# Altium schematic sheet UBX_NORA_B1_SCHEM_0003_Shield_Conn.SchDoc
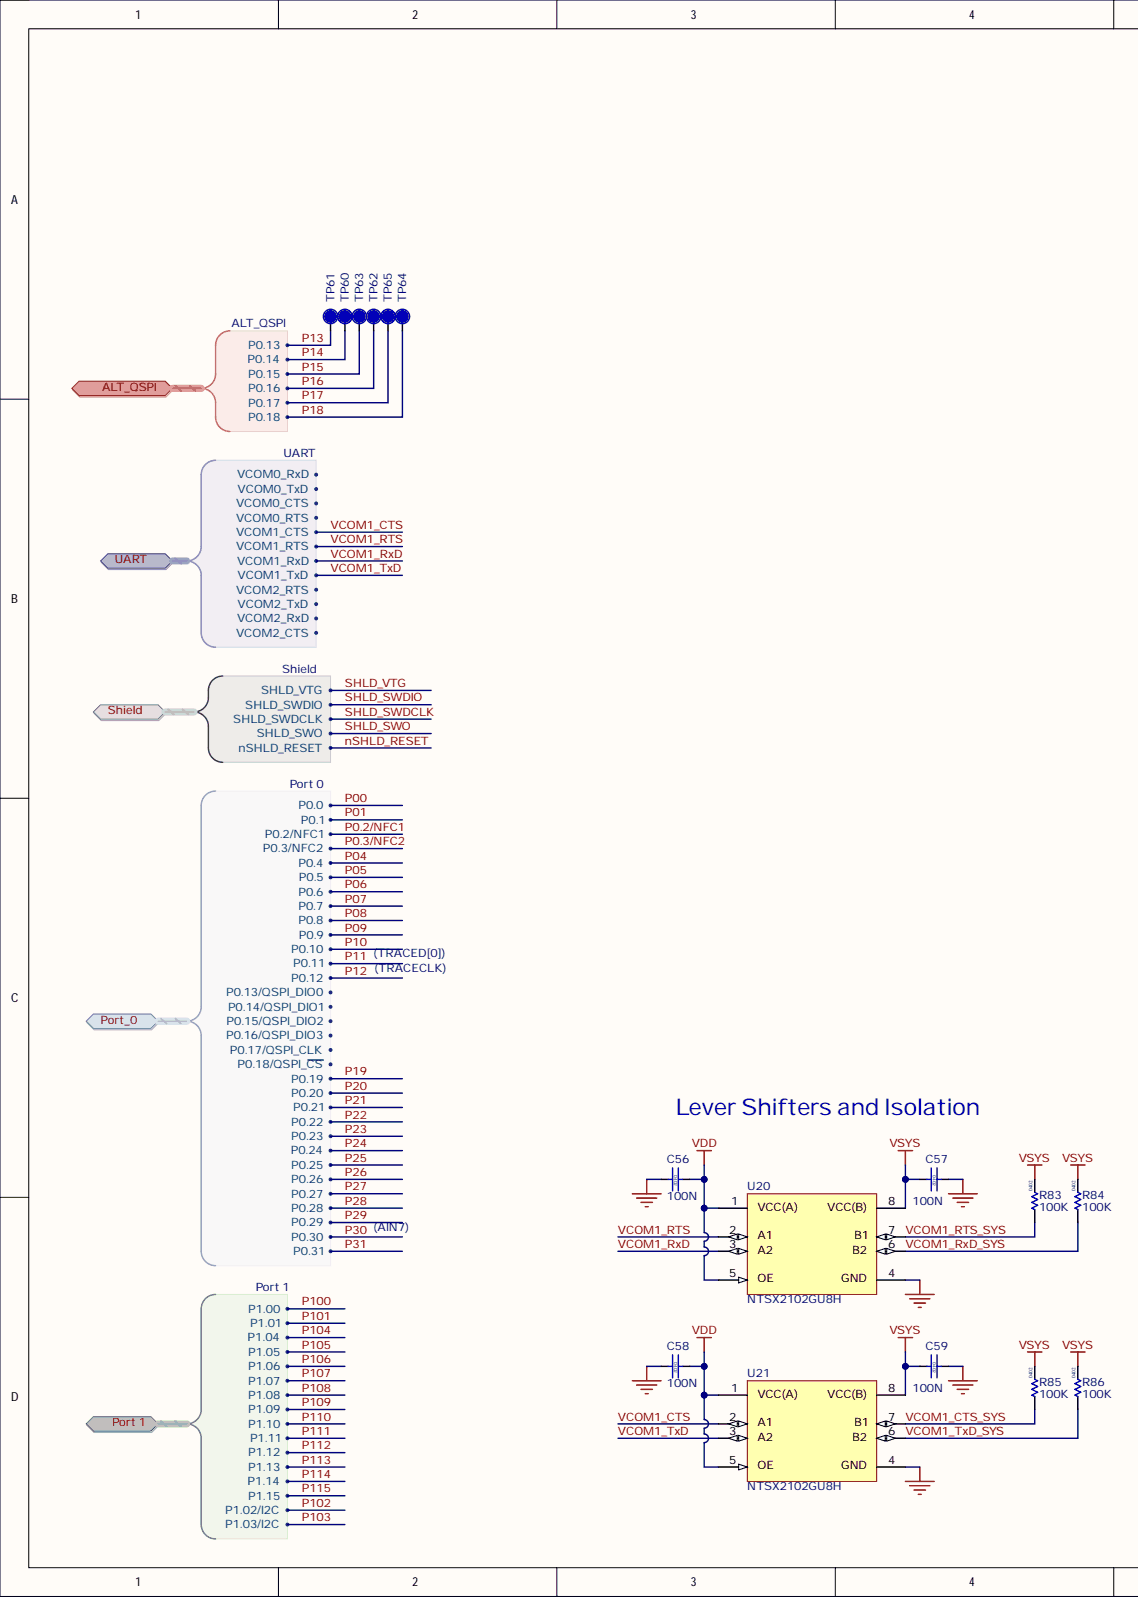
[diagram p0: UBX_NORA_B1_SCHEM_0003_Shield_Conn.SchDoc, left]
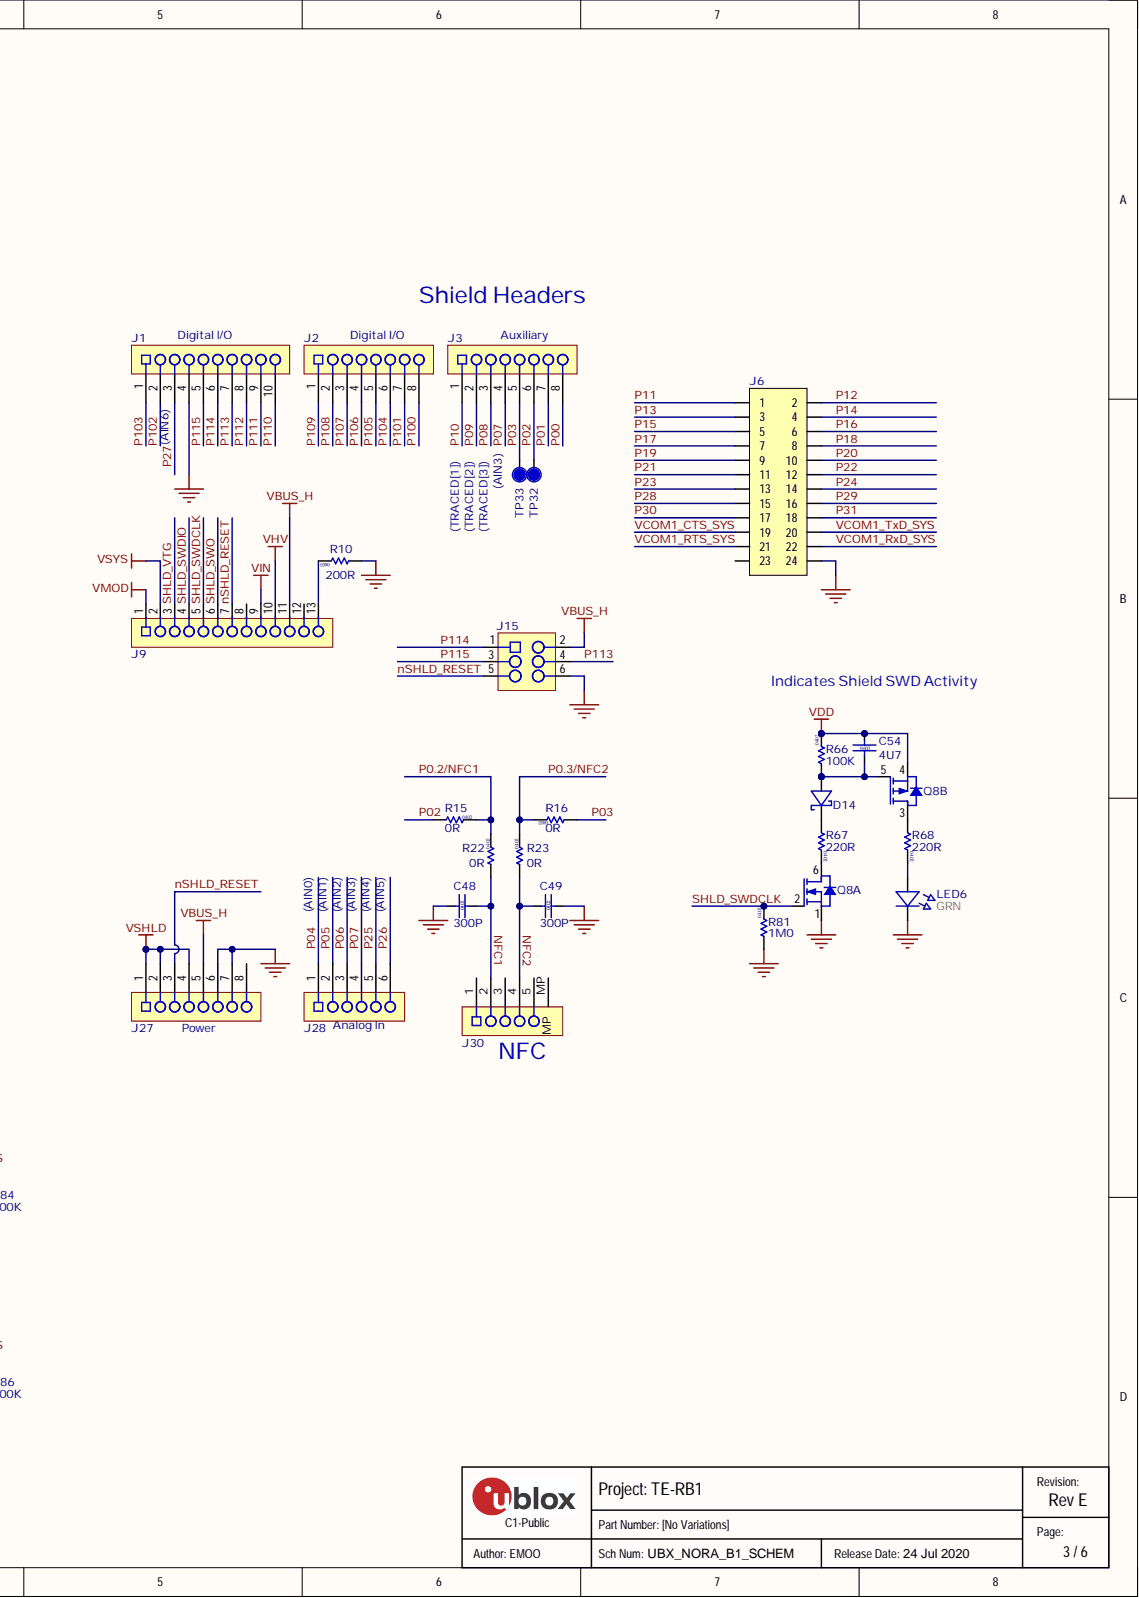
[diagram p1: UBX_NORA_B1_SCHEM_0003_Shield_Conn.SchDoc, right]

## Parts
C48  value 300P  Size: 0402  [Cap 0402 HD]  (hidden comment: UBXH01-0000594300P)
C49  value 300P  Size: 0402  [Cap 0402 HD]  (hidden comment: UBXH01-0000594300P)
C54  value 4U7  Size: 0603  [CAP 0603 HD]  (hidden comment: UBXH01-20020034U7)
C56  value 100N  Size: 0402  [Cap 0402 HD]  (hidden comment: UBXH01-2021002100N)
C57  value 100N  Size: 0402  [Cap 0402 HD]  (hidden comment: UBXH01-2021002100N)
C58  value 100N  Size: 0402  [Cap 0402 HD]  (hidden comment: UBXH01-2021002100N)
C59  value 100N  Size: 0402  [Cap 0402 HD]  (hidden comment: UBXH01-2021002100N)
D14  UBXH07-0000039  [SOD-523]  (value not drawn)
J1  UBXH04-0000788  [SKT-0.1P-SSM-110-L-SV]  (value not drawn)
J2  UBXH04-0000789  [SKT-0.1P-SSM-108-L-SV]  (value not drawn)
J3  UBXH04-0000789  [SKT-0.1P-SSM-108-L-SV]  (value not drawn)
J6  SSM-112-F-DV  [SSM-112-F-DV]  (value not drawn)
J9  UBXH04-0000801  [TSM-113-01-L-SV]  (value not drawn)
J15  UBXH04-0000805  [HDR-0.1P-6Pin-3x2-SMT]  (value not drawn)
J27  UBXH04-0000789  [SKT-0.1P-SSM-108-L-SV]  (value not drawn)
J28  UBXH04-0000790  [SKT-0.1P-SSM-106-L-SV]  (value not drawn)
J30  UBXH04-0000800  [Molex 051281-0594]  (value not drawn)
LED6  Color: GRN  [LED_0603_GREEN]  (hidden comment: UBXH11-0000001)
Q8  UBXH20-0000084  [SOT-563]  (value not drawn)  (parts A,B)
R10  value 200R  Size: 0603  [RES 0603 HD]  (hidden comment: UBXH18-0007056200R)
R15  value 0R  Size: 0402  [RES 0402 HD]  (hidden comment: UBXH18-00030020R)
R16  value 0R  Size: 0402  [RES 0402 HD]  (hidden comment: UBXH18-00030020R)
R22  value 0R  Size: 0402  [RES 0402 HD]  (hidden comment: UBXH18-00030020R)
R23  value 0R  Size: 0402  [RES 0402 HD]  (hidden comment: UBXH18-00030020R)
R66  value 100K  Size: 0402  [RES 0402 HD]  (hidden comment: UBXH18-0000005100K)
R67  value 220R  Size: 0402  [RES 0402 HD]  (hidden comment: UBXH18-0008033220R)
R68  value 220R  Size: 0402  [RES 0402 HD]  (hidden comment: UBXH18-0008033220R)
R81  value 1M0  Size: 0402  [RES 0402 HD]  (hidden comment: UBXH18-00081211M0)
R83  value 100K  Size: 0402  [RES 0402 HD]  (hidden comment: UBXH18-0000005100K)
R84  value 100K  Size: 0402  [RES 0402 HD]  (hidden comment: UBXH18-0000005100K)
R85  value 100K  Size: 0402  [RES 0402 HD]  (hidden comment: UBXH18-0000005100K)
R86  value 100K  Size: 0402  [RES 0402 HD]  (hidden comment: UBXH18-0000005100K)
TP32  TP  [TP10R]  (no BOM)
TP33  TP  [TP10R]  (no BOM)
TP60  TP  [TP10R]  (no BOM)
TP61  TP  [TP10R]  (no BOM)
TP62  TP  [TP10R]  (no BOM)
TP63  TP  [TP10R]  (no BOM)
TP64  TP  [TP10R]  (no BOM)
TP65  TP  [TP10R]  (no BOM)
U20  Manufacturer Part Number 1: NTSX2102GU8H  [QFN0.4P3x1-8Pin]  (hidden comment: UBXH10-0000106)
U21  Manufacturer Part Number 1: NTSX2102GU8H  [QFN0.4P3x1-8Pin]  (hidden comment: UBXH10-0000106)

## Ports
ALT_QSPI, ALT_QSPI.P0.13, ALT_QSPI.P0.14, ALT_QSPI.P0.15, ALT_QSPI.P0.16, ALT_QSPI.P0.17, ALT_QSPI.P0.18, Port 1, Port 1.P1.00, Port 1.P1.01, Port 1.P1.02/I2C, Port 1.P1.03/I2C, Port 1.P1.04, Port 1.P1.05, Port 1.P1.06, Port 1.P1.07, Port 1.P1.08, Port 1.P1.09, Port 1.P1.10, Port 1.P1.11, Port 1.P1.12, Port 1.P1.13, Port 1.P1.14, Port 1.P1.15, Port_0, Port_0.P0.0, Port_0.P0.1, Port_0.P0.2/NFC1, Port_0.P0.3/NFC2, Port_0.P0.4, Port_0.P0.5, Port_0.P0.6, Port_0.P0.7, Port_0.P0.8, Port_0.P0.9, Port_0.P0.10, Port_0.P0.11, Port_0.P0.12, Port_0.P0.13/QSPI_DIO0, Port_0.P0.14/QSPI_DIO1, Port_0.P0.15/QSPI_DIO2, Port_0.P0.16/QSPI_DIO3, Port_0.P0.17/QSPI_CLK, Port_0.P0.18/QSPI_~{CS}, Port_0.P0.19, Port_0.P0.20, Port_0.P0.21, Port_0.P0.22, Port_0.P0.23, Port_0.P0.24, Port_0.P0.25, Port_0.P0.26, Port_0.P0.27, Port_0.P0.28, Port_0.P0.29, Port_0.P0.30, Port_0.P0.31, Shield, Shield.nSHLD_RESET, Shield.SHLD_SWDCLK, Shield.SHLD_SWDIO, Shield.SHLD_SWO, Shield.SHLD_VTG, UART, UART.VCOM0_CTS, UART.VCOM0_RTS, UART.VCOM0_RxD, UART.VCOM0_TxD, UART.VCOM1_CTS, UART.VCOM1_RTS, UART.VCOM1_RxD, UART.VCOM1_TxD, UART.VCOM2_CTS, UART.VCOM2_RTS, UART.VCOM2_RxD, UART.VCOM2_TxD

## Nets
GND: C48.1 C49.2 C56.2 C57.1 C58.2 C59.1 J1.4 J6.24 J15.6 J27.6 J27.7 LED6.1 Q8.1 R10.1 R81.1 U20.4(GND) U21.4(GND)
VBUS_H: J9.11 J15.2 J27.5
VDD: C54.1 C56.1 C58.1 Q8.4 R66.2 U20.1(VCC(A)) U20.5(OE) U21.1(VCC(A)) U21.5(OE)
VHV: J9.10
VIN: J9.9
VMOD: J9.1
VSHLD: J27.1 J27.2 J27.4
VSYS: C57.2 C59.2 J9.2 R83.2 R84.2 R85.2 R86.2 U20.8(VCC(B)) U21.8(VCC(B))
NFC1: C48.2 J30.2 R22.1
NFC2: C49.1 J30.4 R23.1
nSHLD_RESET: J9.7 J15.5 J27.3 ; port Shield
P00: J3.8 ; port Port_0
P0.2/NFC1: R15.2 R22.2 ; port Port_0
P0.3/NFC2: R16.2 R23.2 ; port Port_0
P01: J3.7 ; port Port_0
P02: J3.6 R15.1 TP32.1
P03: J3.5 R16.1 TP33.1
P04: J28.1 ; port Port_0
P05: J28.2 ; port Port_0
P06: J28.3 ; port Port_0
P07: J3.4 J28.4 ; port Port_0
P08: J3.3 ; port Port_0
P09: J3.2 ; port Port_0
P10: J3.1 ; port Port_0
P11: J6.1 ; port Port_0
P12: J6.2 ; port Port_0
P13: J6.3 TP61.1 ; port ALT_QSPI
P14: J6.4 TP60.1 ; port ALT_QSPI
P15: J6.5 TP63.1 ; port ALT_QSPI
P16: J6.6 TP62.1 ; port ALT_QSPI
P17: J6.7 TP65.1 ; port ALT_QSPI
P18: J6.8 TP64.1 ; port ALT_QSPI
P19: J6.9 ; port Port_0
P20: J6.10 ; port Port_0
P21: J6.11 ; port Port_0
P22: J6.12 ; port Port_0
P23: J6.13 ; port Port_0
P24: J6.14 ; port Port_0
P25: J28.5 ; port Port_0
P26: J28.6 ; port Port_0
P27: J1.3 ; port Port_0
P28: J6.15 ; port Port_0
P29: J6.16 ; port Port_0
P30: J6.17 ; port Port_0
P31: J6.18 ; port Port_0
P100: J2.8 ; port Port 1
P101: J2.7 ; port Port 1
P102: J1.2 ; port Port 1
P103: J1.1 ; port Port 1
P104: J2.6 ; port Port 1
P105: J2.5 ; port Port 1
P106: J2.4 ; port Port 1
P107: J2.3 ; port Port 1
P108: J2.2 ; port Port 1
P109: J2.1 ; port Port 1
P110: J1.10 ; port Port 1
P111: J1.9 ; port Port 1
P112: J1.8 ; port Port 1
P113: J1.7 J15.4 ; port Port 1
P114: J1.6 J15.1 ; port Port 1
P115: J1.5 J15.3 ; port Port 1
SHLD_SWDCLK: J9.5 Q8.2 R81.2 ; port Shield
SHLD_SWDIO: J9.4 ; port Shield
SHLD_SWO: J9.6 ; port Shield
SHLD_VTG: J9.3 ; port Shield
VCOM1_CTS: U21.2(A1) ; port UART
VCOM1_CTS_SYS: J6.19 R85.1 U21.7(B1)
VCOM1_RTS: U20.2(A1) ; port UART
VCOM1_RTS_SYS: J6.21 R83.1 U20.7(B1)
VCOM1_RxD: U20.3(A2) ; port UART
VCOM1_RxD_SYS: J6.22 R84.1 U20.6(B2)
VCOM1_TxD: U21.3(A2) ; port UART
VCOM1_TxD_SYS: J6.20 R86.1 U21.6(B2)
NetC54_2: C54.2 D14.A Q8.5 R66.1
NetD14_C: D14.C R67.1
NetJ9_13: J9.13 R10.2
NetLED6_2: LED6.2 R68.2
NetQ8_3: Q8.3 R68.1
NetQ8_6: Q8.6 R67.2
N00001: ; port UART
N00002: ; port UART
N00003: ; port UART
N00004: ; port UART
N00005: ; port UART
N00006: ; port UART
N00007: ; port UART
N00008: ; port UART
N00009: ; port Port_0
N00010: ; port Port_0
N00011: ; port Port_0
N00012: ; port Port_0
N00013: ; port Port_0
N00014: ; port Port_0

Not connected: J6 23; J9 8 12; J27 8; J30 1 3 5 MP

## Library data of the parts
C48 C49 = UBXH01-0000594: CAP CER C0G 0402 300P 50V; Manufacturer: Murata Manufacturing Company, Ltd.; Manufacturer P/N: GRM1555C1H301JA01D; Database Table Name: Passive - Capacitor; Editor: eric.moog; Env: ROHS; Mfg P/N Released: No; Mfg P/N Split: 100; Mfg P/N Status: Active; Perferred: PREF; Permitted Use: Volume Products; Component Kind: Standard; Library Name: UBX_Parts_DB_Lib.DbLib; Max Operating Temp: 125°C; Max Voltage: 50V; Min Operating Temp: -55°C; Part Number: UBXH01-0000594; Part Type: Capacitor; RefDes: C; Signal Integrity: Cap Semi; Simulation: CAP; UBX Wiki: https://wiki.u-blox.com/bin/view/Operations/PartDetails?index=38856
C54 = UBXH01-2002003: CAP CER X5R 0603 4U7 10% 6.3V; Manufacturer: Murata Manufacturing Company, Ltd.; Manufacturer P/N: GRM188R61C475KE11; Database Table Name: Passive - Capacitor; Editor: philippe.largier; Env: GREEN; Mfg P/N Released: Yes; Mfg P/N Split: 100; Mfg P/N Status: Active; Perferred: PREF; Permitted Use: Volume Products; Released by: roland.rust; Component Kind: Standard; Library Name: UBX_Parts_DB_Lib.DbLib; Manufacturer 2: Murata Manufacturing Company, Ltd.; Manufacturer 3: Samsung Electro-Mechanics; Manufacturer P/N 2: GRM188R61E475KE11; Manufacturer P/N 3: CL10A475KO8NNN; Max Voltage: 6.3V; Mfg P/N Datasheet: https://wiki.u-blox.com/bin/view/Generic/Docs/DocumentSummary?index=30154; Mfg P/N Datasheet 2: https://wiki.u-blox.com/bin/view/Generic/Docs/DocumentSummary?index=30500; Mfg P/N Datasheet 3: https://wiki.u-blox.com/bin/view/Generic/Docs/DocumentSummary?index=28177; Mfg P/N Released 2: Yes; Mfg P/N Released 3: Yes; Mfg P/N Split 2: 0; Mfg P/N Split 3: 0; Mfg P/N Status 2: Active; Mfg P/N Status 3: NRND; Part Number: UBXH01-2002003; Part Type: Capacitor; RefDes: C; Release Data: 2016-02-02T16:39:45; Signal Integrity: Cap Semi; Simulation: CAP; Tolerance: 10%; UBX Wiki: https://wiki.u-blox.com/bin/view/Operations/PartDetails?index=5742
C56-C59 = UBXH01-2021002: CAP CER X5R 0402 100N 10% 10V; Manufacturer: Murata Manufacturing Company, Ltd.; Manufacturer P/N: GRM155R61A104KA01; Database Table Name: Passive - Capacitor; Editor: roland.rust; Env: GREEN; Mfg P/N Released: Yes; Mfg P/N Split: 100; Mfg P/N Status: NRND; Perferred: PREF; Permitted Use: Volume Products; Component Kind: Standard; Library Name: UBX_Parts_DB_Lib.DbLib; Manufacturer 2: Samsung Electro-Mechanics; Manufacturer P/N 2: CL05A104KP5NNN; Max Voltage: 10V; Mfg P/N Datasheet 2: https://wiki.u-blox.com/bin/view/Generic/Docs/DocumentSummary?index=28113; Mfg P/N Released 2: Yes; Mfg P/N Split 2: 0; Mfg P/N Status 2: Active; Part Number: UBXH01-2021002; Part Type: Capacitor; RefDes: C; Release Data: 2005-07-22T13:59:44; Signal Integrity: Cap Semi; Simulation: CAP; Tolerance: 10%; UBX Wiki: https://wiki.u-blox.com/bin/view/Operations/PartDetails?index=5759; Released by: roland.rust
D14 = UBXH07-0000039: SCHOTTKY BARRIER DIODE 30V 200mA; Manufacturer: ON Semiconductor; Manufacturer P/N: RB521S30T1G; Database Table Name: Discrete - Diode; Editor: matej.budin; Env: ROHS; Mfg P/N Released: Yes; Mfg P/N Split: 100; Mfg P/N Status: Active; Perferred: PREF; Permitted Use: Volume Products; Released by: pierpaolo.arban; Value: RB521S30T1G; Component Kind: Standard; Library Name: UBX_Parts_DB_Lib.DbLib; Max Current: 200mA; Max Voltage: 30V; Mfg P/N Datasheet: https://wiki.u-blox.com/bin/view/Generic/Docs/DocumentSummary?index=22755; Part Number: UBXH07-0000039; Part Type: Diode; RefDes: D; Release Data: 2015-06-18T10:04:48; UBX Wiki: https://wiki.u-blox.com/bin/view/Operations/PartDetails?index=22753
J1 = UBXH04-0000788: 10 -PIN RECEPTACLE BOTTOM OR TOP ENTRY VERT 2.54MM 50V 2.5A; Manufacturer: Amphenol Corporation; Manufacturer P/N: 91601-310GLF; Database Table Name: Electromechanical - Connector; Editor: eric.moog; Env: ROHS; Mfg P/N Released: No; Mfg P/N Split: 100; Mfg P/N Status: Active; Permitted Use: Support Products; Value: 91601-310GLF; Component Kind: Standard; Library Name: UBX_Parts_DB_Lib.DbLib; Max Current: 2.5A; Max Operating Temp: 150°C; Max Voltage: 50V; Mfg P/N Datasheet: https://wiki.u-blox.com/bin/view/Generic/Docs/DocumentSummary?index=38560; Min Operating Temp: -65°C; Part Number: UBXH04-0000788; Part Type: Connector; Perferred: N/A; RefDes: J; UBX Wiki: https://wiki.u-blox.com/bin/view/Operations/PartDetails?index=38561
J2 J3 J27 = UBXH04-0000789: 8 -PIN RECEPTACLE BOTTOM OR TOP ENTRY VERT 2.54MM 50V 2.5A; Manufacturer: Amphenol Corporation; Manufacturer P/N: 91601-308GLF; Database Table Name: Electromechanical - Connector; Editor: eric.moog; Env: ROHS; Mfg P/N Released: No; Mfg P/N Split: 100; Mfg P/N Status: Active; Permitted Use: Support Products; Value: 91601-308GLF; Component Kind: Standard; Library Name: UBX_Parts_DB_Lib.DbLib; Max Current: 2.5A; Max Operating Temp: 150°C; Max Voltage: 50V; Mfg P/N Datasheet: https://wiki.u-blox.com/bin/view/Generic/Docs/DocumentSummary?index=38560; Min Operating Temp: -65°C; Part Number: UBXH04-0000789; Part Type: Connector; Perferred: N/A; RefDes: J; UBX Wiki: https://wiki.u-blox.com/bin/view/Operations/PartDetails?index=38563
J6 = UBXH04-0000802: 2-ROW SMD PCB CONN SOCKET 100MIL GRID 24-PIN 0.64MM SQUARE 405V 5.2A; Manufacturer: Samtec; Artikkelnr Noca: N.A.; Database Table Name: Electromechanical - Connector; Editor: eric.moog; Env: ROHS; Mfg P/N Released: No; Mfg P/N Split: 100; Mfg P/N Status: Active; Perferred: PREF; Permitted Use: Support Products; Component Kind: Standard; ComponentLink1Description: Datasheet; ComponentLink2Description: Supplier link; Footprint Doc: SOCKET_2x12_SMD-2.54mm; LatestRevisionDate: 2019-08-05; Library Name: UBX_Parts_DB_Lib.DbLib; Manufacturer 1: Samtec; Manufacturer P/N: SSM-112-F-DV; Manufacturer Part Number 1: SSM-112-F-DV; Max Current: 5.2A; Max Operating Temp: 125°C; Max Voltage: 405V; Mfg P/N Datasheet: https://wiki.u-blox.com/bin/view/Generic/Docs/DocumentSummary?index=21260; Min Operating Temp: -55°C; Part Number: UBXH04-0000802; Part number IPS: N.A.; Part Type: Connector; Pin Count: 24; RefDes: J; Supplier 1: Cabcon; Supplier 2: Samtec; Supplier Part Number 1: 2171-212MS0CUNR1; Supplier Part Number 2: SSM-112-F-DV; UBX Wiki: https://wiki.u-blox.com/bin/view/Operations/PartDetails?index=38898; Value: SSM-112-F-DV
J9 = UBXH04-0000801: 1-ROW SMD-PCB CONN 13 -PIN 2.54MM STRIP 475V 4.1A; Manufacturer: Samtec; Database Table Name: Electromechanical - Connector; Editor: eric.moog; Env: ROHS; Mfg P/N Released: No; Mfg P/N Split: 100; Mfg P/N Status: Active; Perferred: PREF; Permitted Use: Support Products; Value: TSM-113-01-L-SV; Component Kind: Standard; Library Name: UBX_Parts_DB_Lib.DbLib; Manufacturer P/N: TSM-113-01-L-SV; Max Current: 4.1A; Max Operating Temp: 125°C; Max Voltage: 475V; Mfg P/N Datasheet: https://wiki.u-blox.com/bin/view/Generic/Docs/DocumentSummary?index=15851; Min Operating Temp: -55°C; Part Number: UBXH04-0000801; Part Type: Connector; RefDes: J; UBX Wiki: https://wiki.u-blox.com/bin/view/Operations/PartDetails?index=38895
J15 = UBXH04-0000805: 2-ROW CONN SMD VERT 2X06 PIN 2.54MM 100V 2A; Manufacturer: molex; Manufacturer P/N: 0015912060; Database Table Name: Electromechanical - Connector; Editor: eric.moog; Env: ROHS; Mfg P/N Released: No; Mfg P/N Split: 100; Mfg P/N Status: Active; Perferred: PREF; Permitted Use: Support Products; Value: 0015912060; Component Kind: Standard; Library Name: UBX_Parts_DB_Lib.DbLib; Max Current: 2A; Max Operating Temp: 85°C; Max Voltage: 100V; Mfg P/N Datasheet: https://wiki.u-blox.com/bin/view/Generic/Docs/DocumentSummary?index=38946; Min Operating Temp: -40°C; Part Number: UBXH04-0000805; Part Type: Connector; RefDes: J; UBX Wiki: https://wiki.u-blox.com/bin/view/Operations/PartDetails?index=38947
J28 = UBXH04-0000790: 6 -PIN RECEPTACLE BOTTOM OR TOP ENTRY VERT 2.54MM 50V 2.5A; Manufacturer: Amphenol Corporation; Manufacturer P/N: 91601-306GLF; Database Table Name: Electromechanical - Connector; Editor: eric.moog; Env: ROHS; Mfg P/N Released: No; Mfg P/N Split: 100; Mfg P/N Status: Active; Permitted Use: Support Products; Value: 91601-306GLF; Component Kind: Standard; Library Name: UBX_Parts_DB_Lib.DbLib; Max Current: 2.5A; Max Operating Temp: 150°C; Max Voltage: 50V; Mfg P/N Datasheet: https://wiki.u-blox.com/bin/view/Generic/Docs/DocumentSummary?index=38560; Min Operating Temp: -65°C; Part Number: UBXH04-0000790; Part Type: Connector; Perferred: N/A; RefDes: J; UBX Wiki: https://wiki.u-blox.com/bin/view/Operations/PartDetails?index=38565
J30 = UBXH04-0000800: CONN NON ZIF FPC MOLEX 51281 0.5MM PITCH 5-PIN TOP AND BOTTOM 50V 500mA; Manufacturer 1: KYOCERA AVX; Manufacturer P/N: 0512810598; Manufacturer Part Number 1: 046284005001846; Part Name: CON FFC/FPC 0.50mm 5Pos R/A; Part_Type: Connector; Component Mounting Type: SMT; Database Table Name: Electromechanical - Connector; Editor: eric.moog; Env: ROHS; Item_Phase: Preliminary; Keyed: No; Mfg P/N Split: 100; Mfg P/N Status: Active; Perferred: PREF; Permitted Use: Support Products; Rows: 1; Use in new designs: Yes; Value: 0512810598; Color: White; Component Kind: Standard; Connector Type: FPC; Height: 1.3mm; Length: 4.05mm; Library Name: UBX_Parts_DB_Lib.DbLib; Locking: No; Manufacturer: molex; Max Current: 500mA; Max Operating Temp: 85°C; Max Voltage: 50V; Mfg P/N Datasheet: https://wiki.u-blox.com/bin/view/Generic/Docs/DocumentSummary?index=38892; Mfg P/N Released: No; Min Operating Temp: -30°C; Part Number: UBXH04-0000800; Part Type: Connector; Pin Pitch: 0.5mm; Pins: 5; RefDes: J; UBX Wiki: https://wiki.u-blox.com/bin/view/Operations/PartDetails?index=38893; Voltage: 50V; Width: 4.4mm
LED6 = UBXH11-0000001: LED SMD 0603 GREEN 2.5V 20mA; Manufacturer: Kingbright; Manufacturer P/N: APT1608SGC; Database Table Name: Discrete - LED; Editor: gianni.falleroni; Env: ROHS; Mfg P/N Released: Yes; Mfg P/N Split: 50; Mfg P/N Status: Active; Permitted Use: Support Products; Released by: alex.claville; Component Kind: Standard; Library Name: UBX_Parts_DB_Lib.DbLib; Manufacturer 2: Lite-on Technology Corporation; Manufacturer P/N 2: LTST-C190KGKT; Max Current: 20mA; Max Voltage: 2.5V; Mfg P/N Datasheet: https://wiki.u-blox.com/bin/view/Generic/Docs/DocumentSummary?index=37527; Mfg P/N Datasheet 2: https://wiki.u-blox.com/bin/view/Generic/Docs/DocumentSummary?index=16220; Mfg P/N Released 2: Yes; Mfg P/N Split 2: 50; Mfg P/N Status 2: Active; Part Number: UBXH11-0000001; Part Type: LED; Perferred: N/A; RefDes: DS; Release Data: 2022-09-27T14:26:54; Size: 0603; UBX Wiki: https://wiki.u-blox.com/bin/view/Operations/PartDetails?index=7902; Value: GREEN
Q8 = UBXH20-0000084: SMALL SIGNAL COMPLEMENTARY W/ ESD PROTECTION MOSFET SOT-563-6 PACKAGE; Manufacturer: ON Semiconductor; Manufacturer P/N: NTZD3155CT1G; Database Table Name: Discrete - Transistor; Editor: pierpaolo.arban; Env: ROHS; Mfg P/N Released: Yes; Mfg P/N Split: 100; Mfg P/N Status: Active; Perferred: PREF; Permitted Use: Support Products; Released by: matej.budin; Value: NTZD3155CT1G; Component Kind: Standard; Library Name: UBX_Parts_DB_Lib.DbLib; Max Operating Temp: 155°C; Mfg P/N Datasheet: https://wiki.u-blox.com/bin/view/Generic/Docs/DocumentSummary?index=9037; Min Operating Temp: -55°C; Part Number: UBXH20-0000084; Part Type: Transistor; RefDes: Q; Release Data: 2023-01-26T11:59:16; UBX Wiki: https://wiki.u-blox.com/bin/view/Operations/PartDetails?index=37717
R10 = UBXH18-0007056: RES THICK FILM CHIP 0603 200R 5% 100mW; Database Table Name: Passive - Resistor; Editor: andreas.thiel; Env: ROHS; Perferred: NONPREF; Permitted Use: Volume Products; Component Kind: Standard; Library Name: UBX_Parts_DB_Lib.DbLib; Max Power: 100mW; Part Number: UBXH18-0007056; Part Type: Resistor; RefDes: R; Signal Integrity: Res; Simulation: RESISTOR; Tolerance: 5%; UBX Wiki: https://wiki.u-blox.com/bin/view/Operations/PartDetails?index=6682
R15 R16 R22 R23 = UBXH18-0003002: RES THICK FILM CHIP 0402 0R 0 100mW; Manufacturer: KOA; Manufacturer P/N: RK73Z1ETTD; Database Table Name: Passive - Resistor; Editor: charlotte.biber; Env: ROHS; Mfg P/N Released: Yes; Mfg P/N Split: 100; Mfg P/N Status: NRND; Perferred: PREF; Permitted Use: Volume Products; Released by: peter.mueller; Tolerance: 0; Component Kind: Standard; Library Name: UBX_Parts_DB_Lib.DbLib; Manufacturer 2: YAGEO; Manufacturer P/N 2: 2322_705_91001_L; Max Power: 100mW; Mfg P/N Released 2: Yes; Mfg P/N Split 2: 0; Mfg P/N Status 2: NRND; Part Number: UBXH18-0003002; Part Type: Resistor; RefDes: R; Release Data: 2012-06-05T09:49:55; Signal Integrity: Res; Simulation: RESISTOR; UBX Wiki: https://wiki.u-blox.com/bin/view/Operations/PartDetails?index=6611
R66 R83-R86 = UBXH18-0000005: RES THICK FILM CHIP 0402 100K 1% 63mW; Manufacturer: KOA; Manufacturer P/N: RK73H1ETTP1003F; Database Table Name: Passive - Resistor; Editor: dario.antonaz; Env: ROHS; Mfg P/N Released: Yes; Mfg P/N Split: 100; Mfg P/N Status: Active; Permitted Use: Volume Products; Released by: sandro.sestan; Component Kind: Standard; Library Name: UBX_Parts_DB_Lib.DbLib; Manufacturer 2: ROHM; Manufacturer P/N 2: MCR01MZPF1003; Max Power: 63mW; Mfg P/N Datasheet: https://wiki.u-blox.com/bin/view/Generic/Docs/DocumentSummary?index=8222; Mfg P/N Datasheet 2: https://wiki.u-blox.com/bin/view/Generic/Docs/DocumentSummary?index=8186; Mfg P/N Released 2: Yes; Mfg P/N Split 2: 0; Mfg P/N Status 2: Active; Part Number: UBXH18-0000005; Part Type: Resistor; Perferred: N/A; RefDes: R; Release Data: 2009-11-30T11:05:22; Signal Integrity: Res; Simulation: RESISTOR; Tolerance: 1%; UBX Wiki: https://wiki.u-blox.com/bin/view/Operations/PartDetails?index=8114
R67 R68 = UBXH18-0008033: RES THICK FILM CHIP 0402 220R 5% 100mW; Manufacturer: ROHM; Manufacturer P/N: MCR01MZPJ221; Database Table Name: Passive - Resistor; Editor: roland.rust; Env: ROHS; Mfg P/N Released: Yes; Mfg P/N Split: 100; Mfg P/N Status: Active; Perferred: PREF; Permitted Use: Volume Products; Component Kind: Standard; Library Name: UBX_Parts_DB_Lib.DbLib; Manufacturer 2: KOA; Manufacturer 3: YAGEO; Manufacturer P/N 2: RK73B1ETTP221J; Manufacturer P/N 3: 2322_705_87221_L; Max Power: 100mW; Mfg P/N Released 2: Yes; Mfg P/N Released 3: Yes; Mfg P/N Split 2: 0; Mfg P/N Split 3: 0; Mfg P/N Status 2: Active; Mfg P/N Status 3: NRND; Part Number: UBXH18-0008033; Part Type: Resistor; RefDes: R; Release Data: 2009-04-24T14:36:39; Signal Integrity: Res; Simulation: RESISTOR; Tolerance: 5%; UBX Wiki: https://wiki.u-blox.com/bin/view/Operations/PartDetails?index=6914; Released by: roland.rust
R81 = UBXH18-0008121: RES THICK FILM CHIP 0402 1M0 5% 63mW; Manufacturer: KOA; Manufacturer P/N: RK73B1ETTP105J; Database Table Name: Passive - Resistor; Editor: daniel.hurni; Env: ROHS; Mfg P/N Released: Yes; Mfg P/N Split: 100; Mfg P/N Status: Active; Perferred: PREF; Permitted Use: Volume Products; Released by: doris.zahnd; Component Kind: Standard; Library Name: UBX_Parts_DB_Lib.DbLib; Max Operating Temp: 125°C; Max Power: 63mW; Mfg P/N Datasheet: https://wiki.u-blox.com/bin/view/Generic/Docs/DocumentSummary?index=4543; Min Operating Temp: -55°C; Part Number: UBXH18-0008121; Part Type: Resistor; RefDes: R; Release Data: 2009-12-01T17:35:03; Signal Integrity: Res; Simulation: RESISTOR; Tolerance: 5%; UBX Wiki: https://wiki.u-blox.com/bin/view/Operations/PartDetails?index=7052
TP32 TP33 TP60-TP65 = UBXH00-0000096: TESTPOINT 1MM CIRCULAR; Database Table Name: Printed Circuit Element - Testpoint; Editor: eric.moog; Perferred: PREF; Permitted Use: Volume Products; Env: N/A; Library Name: UBX_Parts_DB_Lib.DbLib; Max Operating Temp: 125°C; Min Operating Temp: -55°C; Part Number: UBXH00-0000096; Part Type: Testpoint; RefDes: TP; UBX Wiki: https://wiki.u-blox.com/bin/view/Operations/PartDetails?index=38784
U20 U21 = UBXH10-0000106: 2-BIT BIDIRECTIONAL TRANSLATING TRANSCEIVER AUTO DIRECTION SENSING OPEN DRAIN XQFN8 5.5V; Manufacturer: NXP; Manufacturer 1: NXP Semiconductors; Manufacturer P/N: NTSX2102GU8; Part Name: IC TXRX TRANSLATING 8XQFN; Part_Type: Digital Logic; Body Size: 1.4mm X 1.2mm; Component Mounting Type: SMT; Database Table Name: IC - Interface; Editor: eric.moog; Env: ROHS; Logic Type: Level Translator; Mfg P/N Released: No; Mfg P/N Split: 100; Mfg P/N Status: Active; MFG Package: XFQFN-8; Number of Circuits: 1; Perferred: PREF; Permitted Use: Volume Products; SMT Pitch: 0.4mm; Use in new designs: Yes; Value: NTSX2102GU8; Component Kind: Standard; Height: 0.5mm; Library Name: UBX_Parts_DB_Lib.DbLib; Max Operating Temp: 85°C; Max Voltage: 5.5V; Mfg P/N Datasheet: https://wiki.u-blox.com/bin/view/Generic/Docs/DocumentSummary?index=38756; Min Operating Temp: -40°C; Package Type: QFN; Part Number: UBXH10-0000106; Part Type: Interface; Pins: 8; RefDes: U; UBX Wiki: https://wiki.u-blox.com/bin/view/Operations/PartDetails?index=38826; Voltage: 5.5V
